# Altium schematic sheet Gen.SchDoc(Gen)
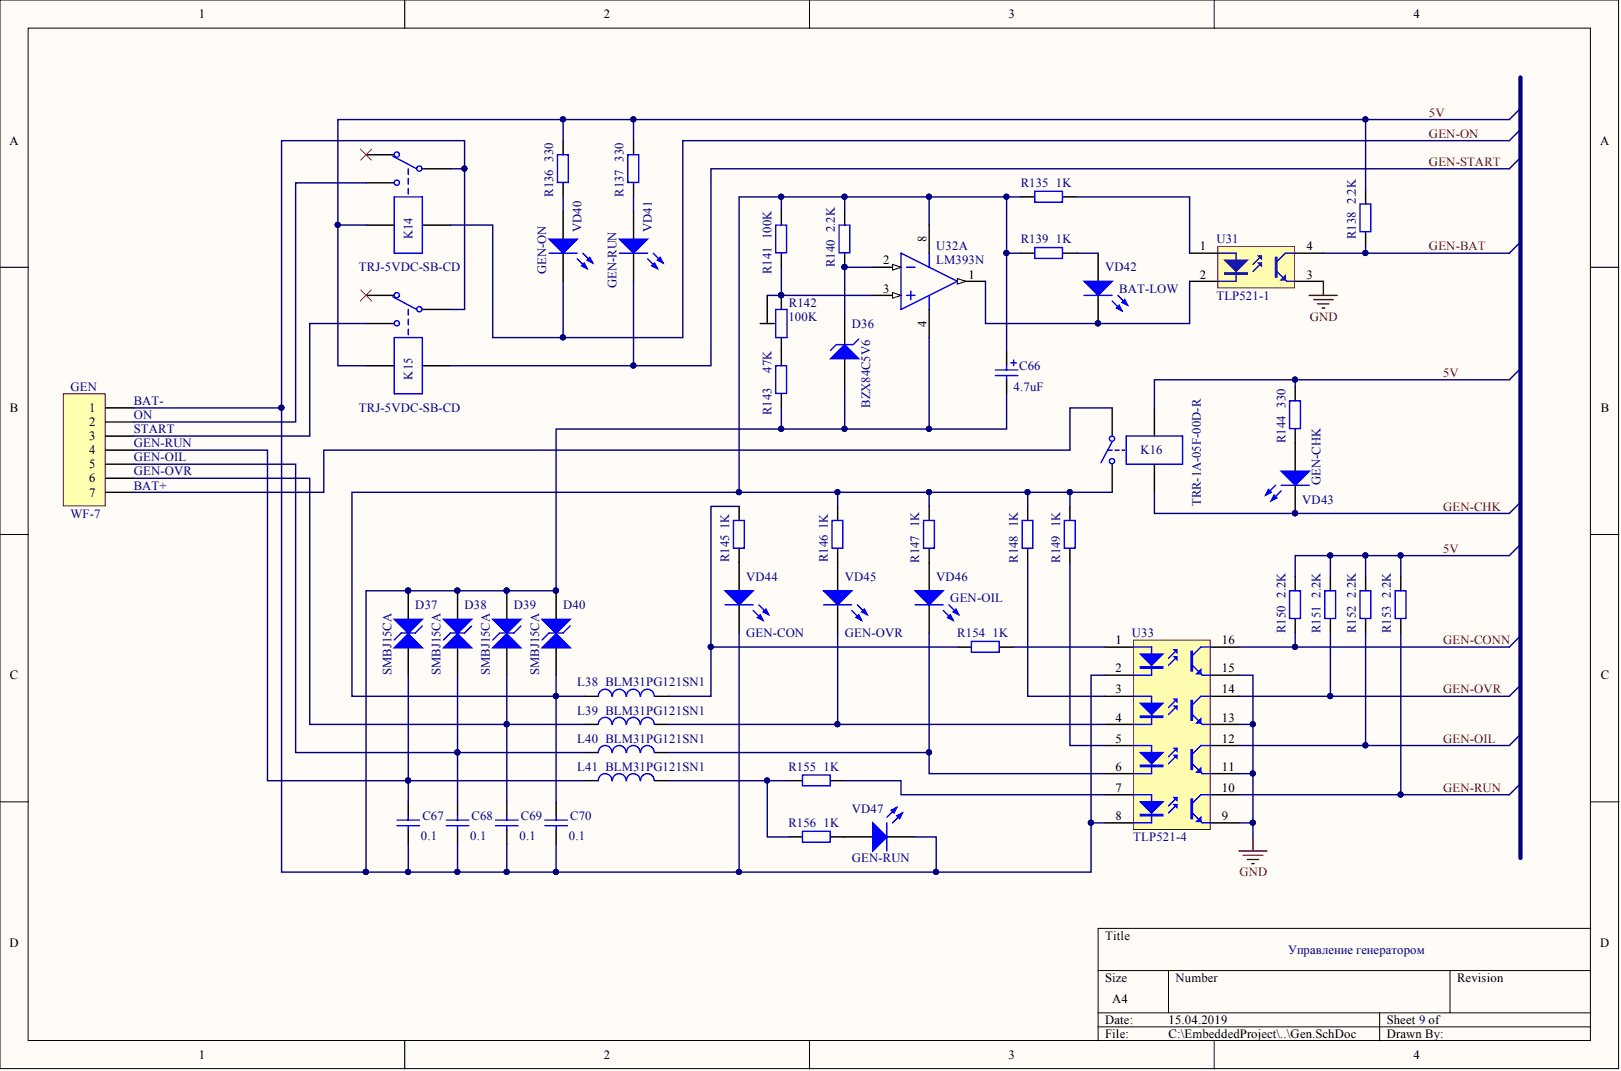
[diagram p0: Gen.SchDoc]

## Parts
C66  value 4.7uF  [D]  (hidden comment: Polarized Capacitor)
C67  value 0.1  [C1206]  (hidden comment: Cap)
C68  value 0.1  [C1206]  (hidden comment: Cap)
C69  value 0.1  [C1206]  (hidden comment: Cap)
C70  value 0.1  [C1206]  (hidden comment: Cap)
D36  value BZX84C5V6  [SOT23_BAT54]  (hidden comment: Zener Diode)
D37  SMBJ15CA  [DO-214AA]
D38  SMBJ15CA  [DO-214AA]
D39  SMBJ15CA  [DO-214AA]
D40  SMBJ15CA  [DO-214AA]
GEN  value WF-7  [WF-7]  (hidden comment: Header 7)
K14  value TRJ-5VDC-SB-CD  [TRJ-5VDC-SB-CD]  (hidden comment: Single-Pole Dual-Throw Relay)
K15  value TRJ-5VDC-SB-CD  [TRJ-5VDC-SB-CD]  (hidden comment: Single-Pole Dual-Throw Relay)
K16  value TRR-1A-05F-00D-R  [TRR-1A-05F-00D-R]  (hidden comment: Single-Pole Single-Throw Relay)
L38  value BLM31PG121SN1  [L1206]  (hidden comment: Ferrite Chip Beads)
L39  value BLM31PG121SN1  [L1206]  (hidden comment: Ferrite Chip Beads)
L40  value BLM31PG121SN1  [L1206]  (hidden comment: Ferrite Chip Beads)
L41  value BLM31PG121SN1  [L1206]  (hidden comment: Ferrite Chip Beads)
R135  value 1K  [1206]  (hidden comment: Res2)
R136  value 330  [1206]  (hidden comment: Res2)
R137  value 330  [1206]  (hidden comment: Res2)
R138  value 2.2K  [1206]  (hidden comment: Res2)
R139  value 1K  [1206]  (hidden comment: Res2)
R140  value 2.2K  [1206]  (hidden comment: Res2)
R141  value 100K  [1206]  (hidden comment: Res2)
R142  value 100K  [RES-3296W]  (hidden comment: Resistor TAP)
R143  value 47K  [1206]  (hidden comment: Res2)
R144  value 330  [1206]  (hidden comment: Res2)
R145  value 1K  [1206]  (hidden comment: Res2)
R146  value 1K  [1206]  (hidden comment: Res2)
R147  value 1K  [1206]  (hidden comment: Res2)
R148  value 1K  [1206]  (hidden comment: Res2)
R149  value 1K  [1206]  (hidden comment: Res2)
R150  value 2.2K  [1206]  (hidden comment: Res2)
R151  value 2.2K  [1206]  (hidden comment: Res2)
R152  value 2.2K  [1206]  (hidden comment: Res2)
R153  value 2.2K  [1206]  (hidden comment: Res2)
R154  value 1K  [1206]  (hidden comment: Res2)
R155  value 1K  [1206]  (hidden comment: Res2)
R156  value 1K  [1206]  (hidden comment: Res2)
U31  TLP521-1  [DIP-4 - OPTO]
U32  LM393N  [DIP-8]  (part A)
U33  TLP521-4  [DIP-16 - OPTO]
VD40  GEN-ON  [LED1206 - RED]
VD41  GEN-RUN  [LED1206 - RED]
VD42  BAT-LOW  [LED1206 - RED]
VD43  GEN-CHK  [LED1206 - RED]
VD44  GEN-CON  [LED1206 - GREEN]
VD45  GEN-OVR  [LED1206 - RED]
VD46  GEN-OIL  [LED1206 - RED]
VD47  GEN-RUN  [LED1206 - GREEN]

## Nets
GND: U31.3 U33.9 U33.11 U33.13 U33.15
5V: K14.5 K15.5 K16.3 R136.1 R137.1 R138.1 R144.1 R150.1 R151.1 R152.1 R153.1
GEN-BAT: R138.2 U31.4
GEN-CHK: K16.5 VD43.2
GEN-CONN: R150.2 U33.16
GEN-OIL: R152.2 U33.12
GEN-ON: K14.4 VD40.2
GEN-OVR: R151.2 U33.14
GEN-RUN: R153.2 U33.10
GEN-START: K15.4 VD41.2
NetC66_1: C66.1 C70.1 D40.1 K16.7 L38.1 R135.2 R139.2 R140.2 R141.2 R146.1 R147.2 R148.2 R149.1 U32.8
NetC66_2: C66.2 C67.2 C68.2 C69.2 C70.2 D36.1 D37.2 D38.2 D39.2 D40.2 GEN.1 K14.1 K15.1 R143.1 U32.4 U33.2 U33.8 VD44.2 VD47.2
NetC67_1: C67.1 D37.1 GEN.4 L41.1
NetC68_1: C68.1 D38.1 GEN.5 L40.1
NetC69_1: C69.1 D39.1 GEN.6 L39.1
NetD36_3: D36.3 R140.1 U32.2
NetGEN_2: GEN.2 K14.3
NetGEN_3: GEN.3 K15.3
NetGEN_7: GEN.7 K16.1
NetL38_2: L38.2 R145.1 R154.2
NetL39_2: L39.2 U33.4 VD45.2
NetL40_2: L40.2 U33.6 VD46.2
NetL41_2: L41.2 R155.2 R156.2
NetR135_1: R135.1 U31.1
NetR136_2: R136.2 VD40.1
NetR137_2: R137.2 VD41.1
NetR139_1: R139.1 VD42.1
NetR141_1: R141.1 R142.2 R142.3 U32.3
NetR142_1: R142.1 R143.2
NetR144_2: R144.2 VD43.1
NetR145_2: R145.2 VD44.1
NetR146_2: R146.2 VD45.1
NetR147_1: R147.1 VD46.1
NetR148_1: R148.1 U33.3
NetR149_2: R149.2 U33.5
NetR154_1: R154.1 U33.1
NetR155_1: R155.1 U33.7
NetR156_1: R156.1 VD47.1
NetU31_2: U31.2 U32.1 VD42.2
N00343:

Not connected: K14 2; K15 2

## Library data of the parts
C66 = Cap Pol2: Component Kind: Standard; Description: Polarized Capacitor; LatestRevisionDate: 17-Jul-2002; LatestRevisionNote: Re-released for DXP Platform.; Library Name: Miscellaneous Devices.IntLib; PackageReference: POLAR0.8; Published: 8-Jun-2000; Signal Integrity: Cap Pol; Simulation: CAP; Publisher: Altium Limited
C67-C70 = Cap: Capacitor; Component Kind: Standard; LatestRevisionDate: 17-Jul-2002; LatestRevisionNote: Re-released for DXP Platform.; Library Name: Miscellaneous Devices.IntLib; PackageReference: RAD-0.3; Published: 8-Jun-2000; Signal Integrity: Cap; Simulation: CAP; Publisher: Altium Limited
D36 = BZX84C5V6: Component Kind: Standard; Description: Zener Diode; LatestRevisionDate: 17-Jul-2002; LatestRevisionNote: Re-released for DXP Platform.; Library Name: Schlib1.SchLib; PackageDescription: Diode, Thru-Hole; 2 Leads; 0.7 in Pin Spacing; PackageReference: DIODE-0.7; Published: 8-Jun-2000; Signal Integrity: D Zener; Simulation: ZENER; Publisher: Altium Limited
D37-D40 = SMBJ15CA: Voltage suppressor; Component Kind: Standard; Library Name: Schlib1.SchLib; Value: SMBJ15CA
GEN = Header 7: Header, 7-Pin; Component Kind: Standard; LatestRevisionDate: 17-Jul-2002; LatestRevisionNote: Re-released for DXP Platform.; Library Name: Miscellaneous Connectors.IntLib; Signal Integrity: Connector; Publisher: Altium Limited
K14 K15 = Relay-SPDT: Component Kind: Standard; Description: Single-Pole Dual-Throw Relay; LatestRevisionDate: 17-Jul-2002; LatestRevisionNote: Re-released for DXP Platform.; Library Name: Miscellaneous Devices.IntLib; PackageReference: MODULE5B; Published: 24-Mar-1999; Simulation: SPDTRELAY; Publisher: Altium Limited
K16 = TRR-1A-05F-00D-R: Component Kind: Standard; Description: Single-Pole Single-Throw Relay; LatestRevisionDate: 17-Jul-2002; LatestRevisionNote: Re-released for DXP Platform.; Library Name: Schlib1.SchLib; PackageReference: MODULE4; Published: 24-Mar-1999; Simulation: SPSTRELAY; Publisher: Altium Limited
L38-L41 = Inductor: Code_IEC: 1005; Code_JEITA: 0402; Component Kind: Standard; Description: Ferrite Chip Beads; LatestRevisionDate: 29-May-2009; LatestRevisionNote: IPC-7351 Footprint Added.; Library Name: Miscellaneous Devices.IntLib; PackageDescription: Chip Inductor; PackageReference: 0402-A; Published: 8-Jun-2000; Signal Integrity: Inductor; Simulation: INDUCTOR; Publisher: Altium Limited
R135-R141 R143-R156 = Res2: Resistor; Component Kind: Standard; LatestRevisionDate: 17-Jul-2002; LatestRevisionNote: Re-released for DXP Platform.; Library Name: Miscellaneous Devices.IntLib; PackageReference: AXIAL-0.4; Published: 8-Jun-2000; Signal Integrity: Res; Simulation: RESISTOR; Publisher: Altium Limited
  R144: PackageDescription: Axial Device, Thru-Hole; 2 Leads; 0.4 in Pin Spacing
R142 = Res TAP: Code_IPC: RESC2012; Component Kind: Standard; Description: Resistor TAP; LatestRevisionDate: 29-May-2009; LatestRevisionNote: IPC-7351 Footprint Added.; Library Name: Schlib1.SchLib; PackageDescription: Chip Resistor 0805 Case Size; PackageReference: 6-0805; PackageVersion: Mar-2003; Published: 24-Mar-1999; Publisher: Altium Limited
U31 = TLP521-1: 1 consist of a photo−transistor; Component Kind: Standard; DatasheetVersion: Nov-2003; LatestRevisionDate: 04-Mar-2005; LatestRevisionNote: Sch pins 3 & 4 Swapped.; Library Name: Schlib1.SchLib; PackageReference: DIP-4; Published: 24-Mar-1999; Value: TLP521-1; Publisher: Altium Limited
U32 = LM393N: Low Power Low Offset Voltage Dual Comparator, 8-pin MDIP; Manufacturer: National Semiconductor; CompType: Amplifiers; Code_JEDEC: MS-001-BA; Component Kind: Standard; ComponentLink1Description: Manufacturer URL; ComponentLink1URL: http://www.national.com/; ComponentLink2Description: LM393 Datasheet; ComponentLink2URL: http://www.national.com/ds/LM/LM393.pdf; ComponentLink3Description: LM393 Web Page; ComponentLink3URL: http://www.national.com/pf/LM/LM393.html; ComponentLink4Description: N08E Package Page; ComponentLink4URL: http://www.national.com/packaging/folders/n08e.html; Library Name: National Semiconductor Amplifier.IntLib; PackageDescription: MDIP, .365 x .250 x .130in, 8 Lead, .100in Pitch; PackageReference: N08E; PackageVersion: revG, May-2006; Value: LM393N
U33 = TLP521-4: 4 consist of a photo−transistor; Component Kind: Standard; DatasheetVersion: Nov-2003; LatestRevisionDate: 04-Mar-2005; LatestRevisionNote: Sch pins 3 & 4 Swapped.; Library Name: Schlib1.SchLib; PackageReference: DIP-4; Published: 24-Mar-1999; value: TLP521-4; Publisher: Altium Limited
VD40-VD43 VD45 = LED0: SMD LED; value: RED; Component Kind: Standard; LatestRevisionDate: 08-Jul-2005; LatestRevisionNote: Mech Layer 1 removed.; Library Name: Miscellaneous Devices.IntLib; PackageReference: LED-0; Published: 8-Jun-2000; Simulation: LED0; Publisher: Altium Limited
VD44 VD47 = LED0: SMD LED; value: GREEN; Component Kind: Standard; LatestRevisionDate: 08-Jul-2005; LatestRevisionNote: Mech Layer 1 removed.; Library Name: Miscellaneous Devices.IntLib; PackageReference: LED-0; Published: 8-Jun-2000; Simulation: LED0; Publisher: Altium Limited
VD46 = LED0: SMD LED; value: ORANGE; Component Kind: Standard; LatestRevisionDate: 08-Jul-2005; LatestRevisionNote: Mech Layer 1 removed.; Library Name: Miscellaneous Devices.IntLib; PackageReference: LED-0; Published: 8-Jun-2000; Simulation: LED0; Publisher: Altium Limited
